# Altium schematic sheet FPGA.SchDoc(FPGA)
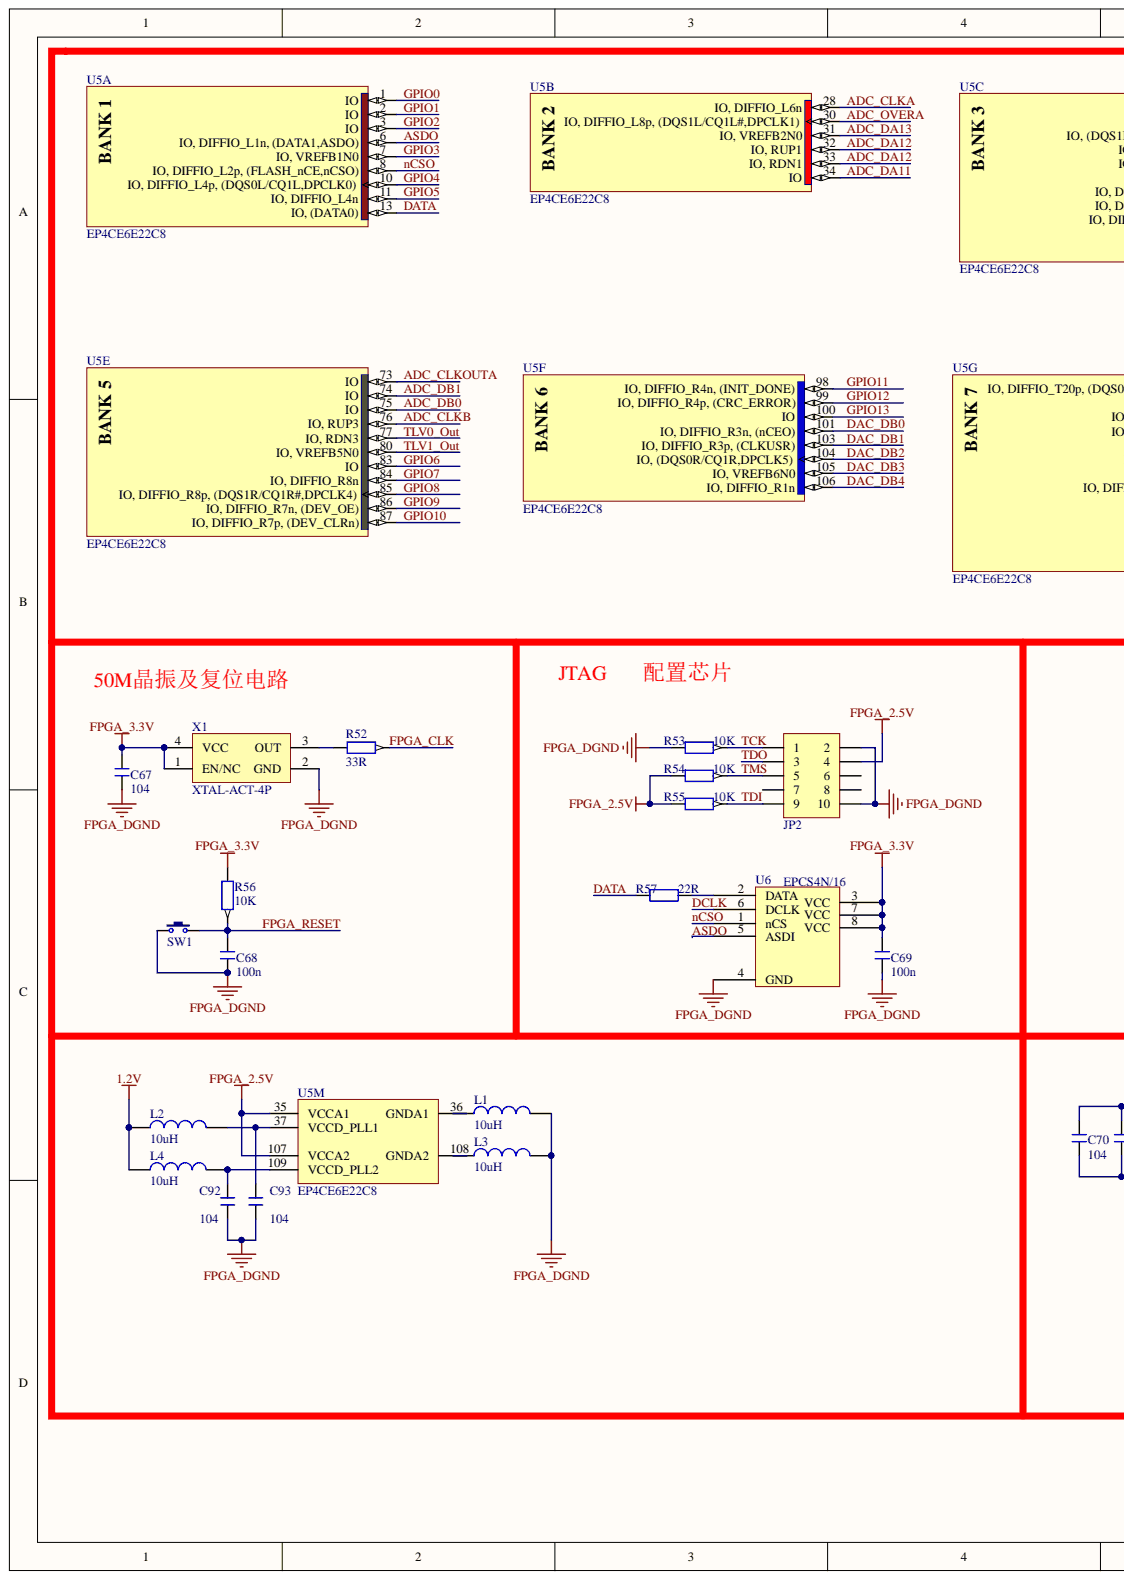
[diagram p0: FPGA.SchDoc, left]
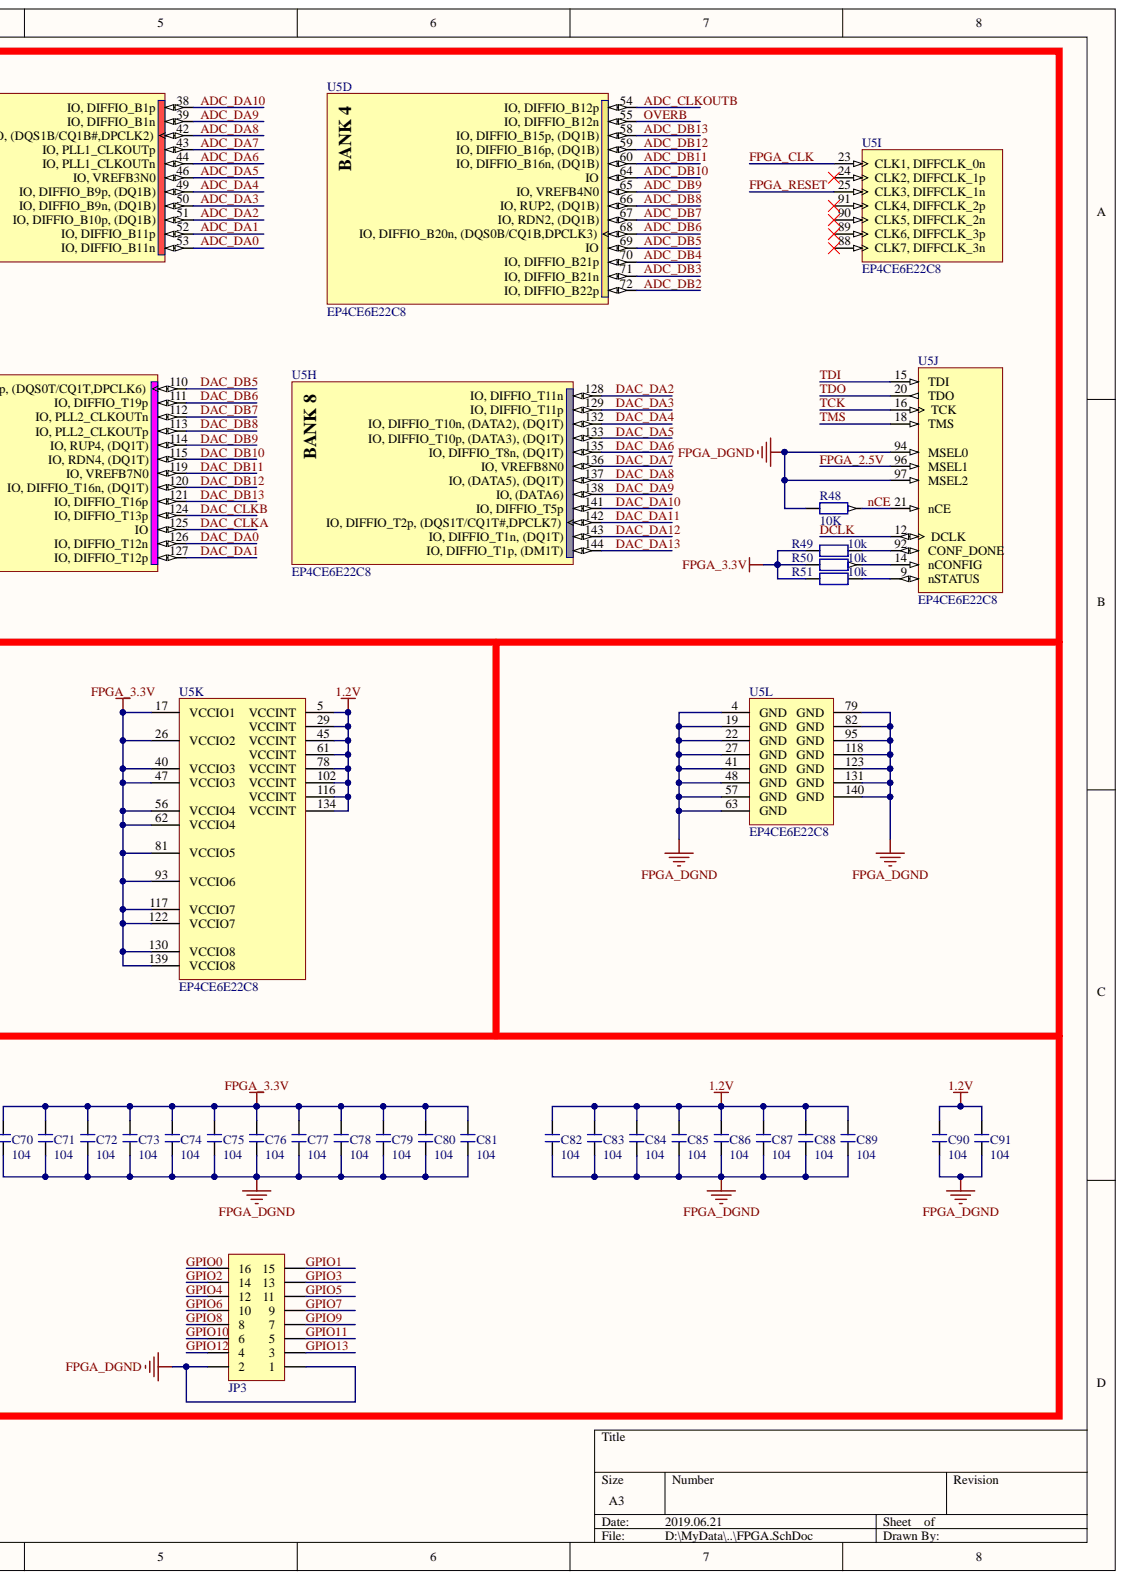
[diagram p1: FPGA.SchDoc, right]

## Parts
C67  104  [C 0805_L]
C68  100n  [C 0805_L]
C69  100n  [C 0805_L]
C70  104  [C 0805_L]
C71  104  [C 0805_L]
C72  104  [C 0805_L]
C73  104  [C 0805_L]
C74  104  [C 0805_L]
C75  104  [C 0805_L]
C76  104  [C 0805_L]
C77  104  [C 0805_L]
C78  104  [C 0805_L]
C79  104  [C 0805_L]
C80  104  [C 0805_L]
C81  104  [C 0805_L]
C82  104  [C 0805_L]
C83  104  [C 0805_L]
C84  104  [C 0805_L]
C85  104  [C 0805_L]
C86  104  [C 0805_L]
C87  104  [C 0805_L]
C88  104  [C 0805_L]
C89  104  [C 0805_L]
C90  104  [C 0805_L]
C91  104  [C 0805_L]
C92  104  [C 0805_L]
C93  104  [C 0805_L]
JP2  Header 5X2  [IDC2.54-LI-2x5P]  (value not drawn)
JP3  Header 8X2  [IDC2.54-LI-2x8P]  (value not drawn)
L1  10uH  [L 0805]
L2  10uH  [L 0805]
L3  10uH  [L 0805]
L4  10uH  [L 0805]
R48  10K  [0603R]
R49  10k  [R 0805_L]
R50  10k  [R 0805_L]
R51  10k  [R 0805_L]
R52  33R  [R 0805_L]
R53  10K  [R 0805_L]
R54  10K  [R 0805_L]
R55  10K  [R 0805_L]
R56  10K  [R 0805_L]
R57  22R  [R 0805_L]
SW1  3x6x5  [TSW SMD-3*6*2.5]  (value not drawn)
U5  EP4CE6E22C8  [EQFP144_N]  (parts A,B,C,D,E,F,G,H,I,J,K,L,M)
U6  EPCS4N/16  [SOP8]
X1  XTAL-ACT-4P  [OSC 1612-4P]

## Nets
1.2V: C82.2 C83.2 C84.2 C85.2 C86.2 C87.2 C88.2 C89.2 C90.2 C91.2 L2.1 L4.1 U5.5(VCCINT) U5.29(VCCINT) U5.45(VCCINT) U5.61(VCCINT) U5.78(VCCINT) U5.102(VCCINT) U5.116(VCCINT) U5.134(VCCINT)
FPGA_3.3V: C67.2 C69.2 C70.2 C71.2 C72.2 C73.2 C74.2 C75.2 C76.2 C77.2 C78.2 C79.2 C80.2 C81.2 R49.1 R50.1 R51.1 R56.2 U5.17(VCCIO1) U5.26(VCCIO2) U5.40(VCCIO3) U5.47(VCCIO3) U5.56(VCCIO4) U5.62(VCCIO4) U5.81(VCCIO5) U5.93(VCCIO6) U5.117(VCCIO7) U5.122(VCCIO7) U5.130(VCCIO8) U5.139(VCCIO8) U6.3(VCC) U6.7(VCC) U6.8(VCC) X1.1(EN/NC) X1.4(VCC)
FPGA_DGND: C67.1 C68.1 C69.1 C70.1 C71.1 C72.1 C73.1 C74.1 C75.1 C76.1 C77.1 C78.1 C79.1 C80.1 C81.1 C82.1 C83.1 C84.1 C85.1 C86.1 C87.1 C88.1 C89.1 C90.1 C91.1 C92.1 C93.1 JP2.2 JP2.10 JP3.1 JP3.2 L1.2 L3.2 R48.1 R53.2 SW1.1 U5.4(GND) U5.19(GND) U5.22(GND) U5.27(GND) U5.41(GND) U5.48(GND) U5.57(GND) U5.63(GND) U5.79(GND) U5.82(GND) U5.94(MSEL0) U5.95(GND) U5.97(MSEL2) U5.118(GND) U5.123(GND) U5.131(GND) U5.140(GND) U6.4(GND) X1.2(GND)
ADC_CLKA: U5.28(IO,_DIFFIO_L6n)
ADC_CLKB: U5.76(IO,_RUP3)
ADC_CLKOUTA: U5.73(IO)
ADC_CLKOUTB: U5.54(IO,_DIFFIO_B12p)
ADC_DA0: U5.53(IO,_DIFFIO_B11n)
ADC_DA1: U5.52(IO,_DIFFIO_B11p)
ADC_DA2: U5.51(IO,_DIFFIO_B10p,_(DQ1B))
ADC_DA3: U5.50(IO,_DIFFIO_B9n,_(DQ1B))
ADC_DA4: U5.49(IO,_DIFFIO_B9p,_(DQ1B))
ADC_DA5: U5.46(IO,_VREFB3N0)
ADC_DA6: U5.44(IO,_PLL1_CLKOUTn)
ADC_DA7: U5.43(IO,_PLL1_CLKOUTp)
ADC_DA8: U5.42(IO,_(DQS1B/CQ1B#,DPCLK2))
ADC_DA9: U5.39(IO,_DIFFIO_B1n)
ADC_DA10: U5.38(IO,_DIFFIO_B1p)
ADC_DA11: U5.34(IO)
ADC_DA12: U5.32(IO,_RUP1) U5.33(IO,_RDN1)
ADC_DA13: U5.31(IO,_VREFB2N0)
ADC_DB0: U5.75(IO)
ADC_DB1: U5.74(IO)
ADC_DB2: U5.72(IO,_DIFFIO_B22p)
ADC_DB3: U5.71(IO,_DIFFIO_B21n)
ADC_DB4: U5.70(IO,_DIFFIO_B21p)
ADC_DB5: U5.69(IO)
ADC_DB6: U5.68(IO,_DIFFIO_B20n,_(DQS0B/CQ1B,DPCLK3))
ADC_DB7: U5.67(IO,_RDN2,_(DQ1B))
ADC_DB8: U5.66(IO,_RUP2,_(DQ1B))
ADC_DB9: U5.65(IO,_VREFB4N0)
ADC_DB10: U5.64(IO)
ADC_DB11: U5.60(IO,_DIFFIO_B16n,_(DQ1B))
ADC_DB12: U5.59(IO,_DIFFIO_B16p,_(DQ1B))
ADC_DB13: U5.58(IO,_DIFFIO_B15p,_(DQ1B))
ADC_OVERA: U5.30(IO,_DIFFIO_L8p,_(DQS1L/CQ1L#,DPCLK1))
ASDO: U5.6(IO,_DIFFIO_L1n,_(DATA1,ASDO)) U6.5(ASDI)
DAC_CLKA: U5.125(IO)
DAC_CLKB: U5.124(IO,_DIFFIO_T13p)
DAC_DA0: U5.126(IO,_DIFFIO_T12n)
DAC_DA1: U5.127(IO,_DIFFIO_T12p)
DAC_DA2: U5.128(IO,_DIFFIO_T11n)
DAC_DA3: U5.129(IO,_DIFFIO_T11p)
DAC_DA4: U5.132(IO,_DIFFIO_T10n,_(DATA2),_(DQ1T))
DAC_DA5: U5.133(IO,_DIFFIO_T10p,_(DATA3),_(DQ1T))
DAC_DA6: U5.135(IO,_DIFFIO_T8n,_(DQ1T))
DAC_DA7: U5.136(IO,_VREFB8N0)
DAC_DA8: U5.137(IO,_(DATA5),_(DQ1T))
DAC_DA9: U5.138(IO,_(DATA6))
DAC_DA10: U5.141(IO,_DIFFIO_T5p)
DAC_DA11: U5.142(IO,_DIFFIO_T2p,_(DQS1T/CQ1T#,DPCLK7))
DAC_DA12: U5.143(IO,_DIFFIO_T1n,_(DQ1T))
DAC_DA13: U5.144(IO,_DIFFIO_T1p,_(DM1T))
DAC_DB0: U5.101(IO,_DIFFIO_R3n,_(nCEO))
DAC_DB1: U5.103(IO,_DIFFIO_R3p,_(CLKUSR))
DAC_DB2: U5.104(IO,_(DQS0R/CQ1R,DPCLK5))
DAC_DB3: U5.105(IO,_VREFB6N0)
DAC_DB4: U5.106(IO,_DIFFIO_R1n)
DAC_DB5: U5.110(IO,_DIFFIO_T20p,_(DQS0T/CQ1T,DPCLK6))
DAC_DB6: U5.111(IO,_DIFFIO_T19p)
DAC_DB7: U5.112(IO,_PLL2_CLKOUTn)
DAC_DB8: U5.113(IO,_PLL2_CLKOUTp)
DAC_DB9: U5.114(IO,_RUP4,_(DQ1T))
DAC_DB10: U5.115(IO,_RDN4,_(DQ1T))
DAC_DB11: U5.119(IO,_VREFB7N0)
DAC_DB12: U5.120(IO,_DIFFIO_T16n,_(DQ1T))
DAC_DB13: U5.121(IO,_DIFFIO_T16p)
DATA: R57.2 U5.13(IO,_(DATA0))
DCLK: U5.12(DCLK) U6.6(DCLK)
FPGA_2.5V: JP2.4 R54.2 R55.2 U5.35(VCCA1) U5.96(MSEL1) U5.107(VCCA2)
FPGA_CLK: R52.2 U5.23(CLK1,_DIFFCLK_0n)
FPGA_RESET: C68.2 R56.1 SW1.2 U5.25(CLK3,_DIFFCLK_1n)
GPIO0: JP3.16 U5.1(IO)
GPIO1: JP3.15 U5.2(IO)
GPIO2: JP3.14 U5.3(IO)
GPIO3: JP3.13 U5.7(IO,_VREFB1N0)
GPIO4: JP3.12 U5.10(IO,_DIFFIO_L4p,_(DQS0L/CQ1L,DPCLK0))
GPIO5: JP3.11 U5.11(IO,_DIFFIO_L4n)
GPIO6: JP3.10 U5.83(IO)
GPIO7: JP3.9 U5.84(IO,_DIFFIO_R8n)
GPIO8: JP3.8 U5.85(IO,_DIFFIO_R8p,_(DQS1R/CQ1R#,DPCLK4))
GPIO9: JP3.7 U5.86(IO,_DIFFIO_R7n,_(DEV_OE))
GPIO10: JP3.6 U5.87(IO,_DIFFIO_R7p,_(DEV_CLRn))
GPIO11: JP3.5 U5.98(IO,_DIFFIO_R4n,_(INIT_DONE))
GPIO12: JP3.4 U5.99(IO,_DIFFIO_R4p,_(CRC_ERROR))
GPIO13: JP3.3 U5.100(IO)
NCE: R48.2 U5.21(nCE)
NCSO: U5.8(IO,_DIFFIO_L2p,_(FLASH_nCE,nCSO)) U6.1(nCS)
OVERB: U5.55(IO,_DIFFIO_B12n)
TCK: JP2.1 R53.1 U5.16(TCK)
TDI: JP2.9 R55.1 U5.15(TDI)
TDO: JP2.3 U5.20(TDO)
TLV0_OUT: U5.77(IO,_RDN3)
TLV1_OUT: U5.80(IO,_VREFB5N0)
TMS: JP2.5 R54.1 U5.18(TMS)
NetC92_2: C92.2 L4.2 U5.109(VCCD_PLL2)
NetC93_2: C93.2 L2.2 U5.37(VCCD_PLL1)
NetL1_1: L1.1 U5.36(GNDA1)
NetL3_1: L3.1 U5.108(GNDA2)
NetR49_2: R49.2 U5.92(CONF_DONE)
NetR50_2: R50.2 U5.14(nCONFIG)
NetR51_2: R51.2 U5.9(nSTATUS)
NetR52_1: R52.1 X1.3(OUT)
NetR57_1: R57.1 U6.2(DATA)

Not connected: JP2 6 7 8; U5 24(CLK2,_DIFFCLK_1p) 88(CLK7,_DIFFCLK_3n) 89(CLK6,_DIFFCLK_3p) 90(CLK5,_DIFFCLK_2n) 91(CLK4,_DIFFCLK_2p)

## Library data of the parts
C67-C93 = C: 无极性贴片电容; 版权归属: 源创客; BOM名称: 无极性贴片电容; Component Kind: Standard; Library Name: 3.1   - 电阻-电容-电感.SchLib; 学习资源: www.ooocke.com
JP2 = Header 5X2: 5*2P接插件; 版权归属: 源创客; BOM名称: 5*2P接插件; Component Kind: Standard; Library Name: 4.5   - 接插件-脚距2.54.SchLib; 学习资源: www.ooocke.com
JP3 = Header 8X2: 8*2P接插件; 版权归属: 源创客; BOM名称: 8*2P接插件; Component Kind: Standard; Library Name: 4.5   - 接插件-脚距2.54.SchLib; 学习资源: www.ooocke.com
L1-L4 = L: 小功率贴片电感; 版权归属: 源创客; BOM名称: 小功率贴片电感; Component Kind: Standard; Library Name: 3.1   - 电阻-电容-电感.SchLib; 学习资源: www.ooocke.com
R48 = Res2: Resistor; Component Kind: Standard; LatestRevisionDate: 17-Jul-2002; LatestRevisionNote: Re-released for DXP Platform.; Library Name: Miscellaneous Devices.IntLib; PackageDescription: Axial Device, Thru-Hole; 2 Leads; 0.4 in Pin Spacing; PackageReference: AXIAL-0.4; Published: 8-Jun-2000; Signal Integrity: Res; Simulation: RESISTOR; Publisher: Altium Limited
R49-R57 = R: 贴片电阻; 版权归属: 源创客; BOM名称: 贴片电阻; Component Kind: Standard; Library Name: 3.1   - 电阻-电容-电感.SchLib; 学习资源: www.ooocke.com
SW1 = TSW 3x6: 3x6轻触开关; 版权归属: 源创客; BOM名称: 3x6轻触开关; Component Kind: Standard; Library Name: 5.1   - 按键-开关.SchLib; 学习资源: www.ooocke.com
U5 = EP4CE6E22C8: Cyclone IV Family FPGA, 2V Core, 91 I/O Pins, 2 PLLs, 144-Pin QFP, Speed Grade 8, Commercial Grade; Code_JEDEC: MS-026-BFB; Component Kind: Standard; ComponentLink1Description: Manufacturer Link; ComponentLink1URL: http://www.altera.com/; ComponentLink2Description: Handbook; ComponentLink2URL: http://www.altera.com/literature/hb/cyclone-iv/cyclone4-handbook.pdf; ComponentLink3Description: Pinout Specification; ComponentLink3URL: http://www.altera.com/literature/lit-dp.jsp?category=cyc%204&showspreadsheet=y; ComponentLink4Description: Packaging Specification; ComponentLink4URL: http://www.altera.com/literature/ds/dspkg.pdf; DatasheetVersion: ver1.2, Mar-2010; Library Name: Altera Cyclone IV E.IntLib; PackageDescription: 144-Pin Plastic Enhanced Quad Flat Pack (EQFP144) - Wire Bond; PackageReference: EQFP144; PackageVersion: ver16.0, Dec-2009; Published: 3-May-2010; Signal Integrity: EP4CE_E144; Supplier 1: Digi-Key; Supplier Part Number 1: EP4CE6E22C8-ND; Publisher: Altium Limited
U6 = EPCS1SI8: Component Kind: Standard; Library Name: M4.SchLib
X1 = XTAL-ACT-4P: 4脚有源晶振; Component Kind: Standard; Library Name: 晶振.IntLib
